# Altium schematic sheet Peripheral_1.SchDoc
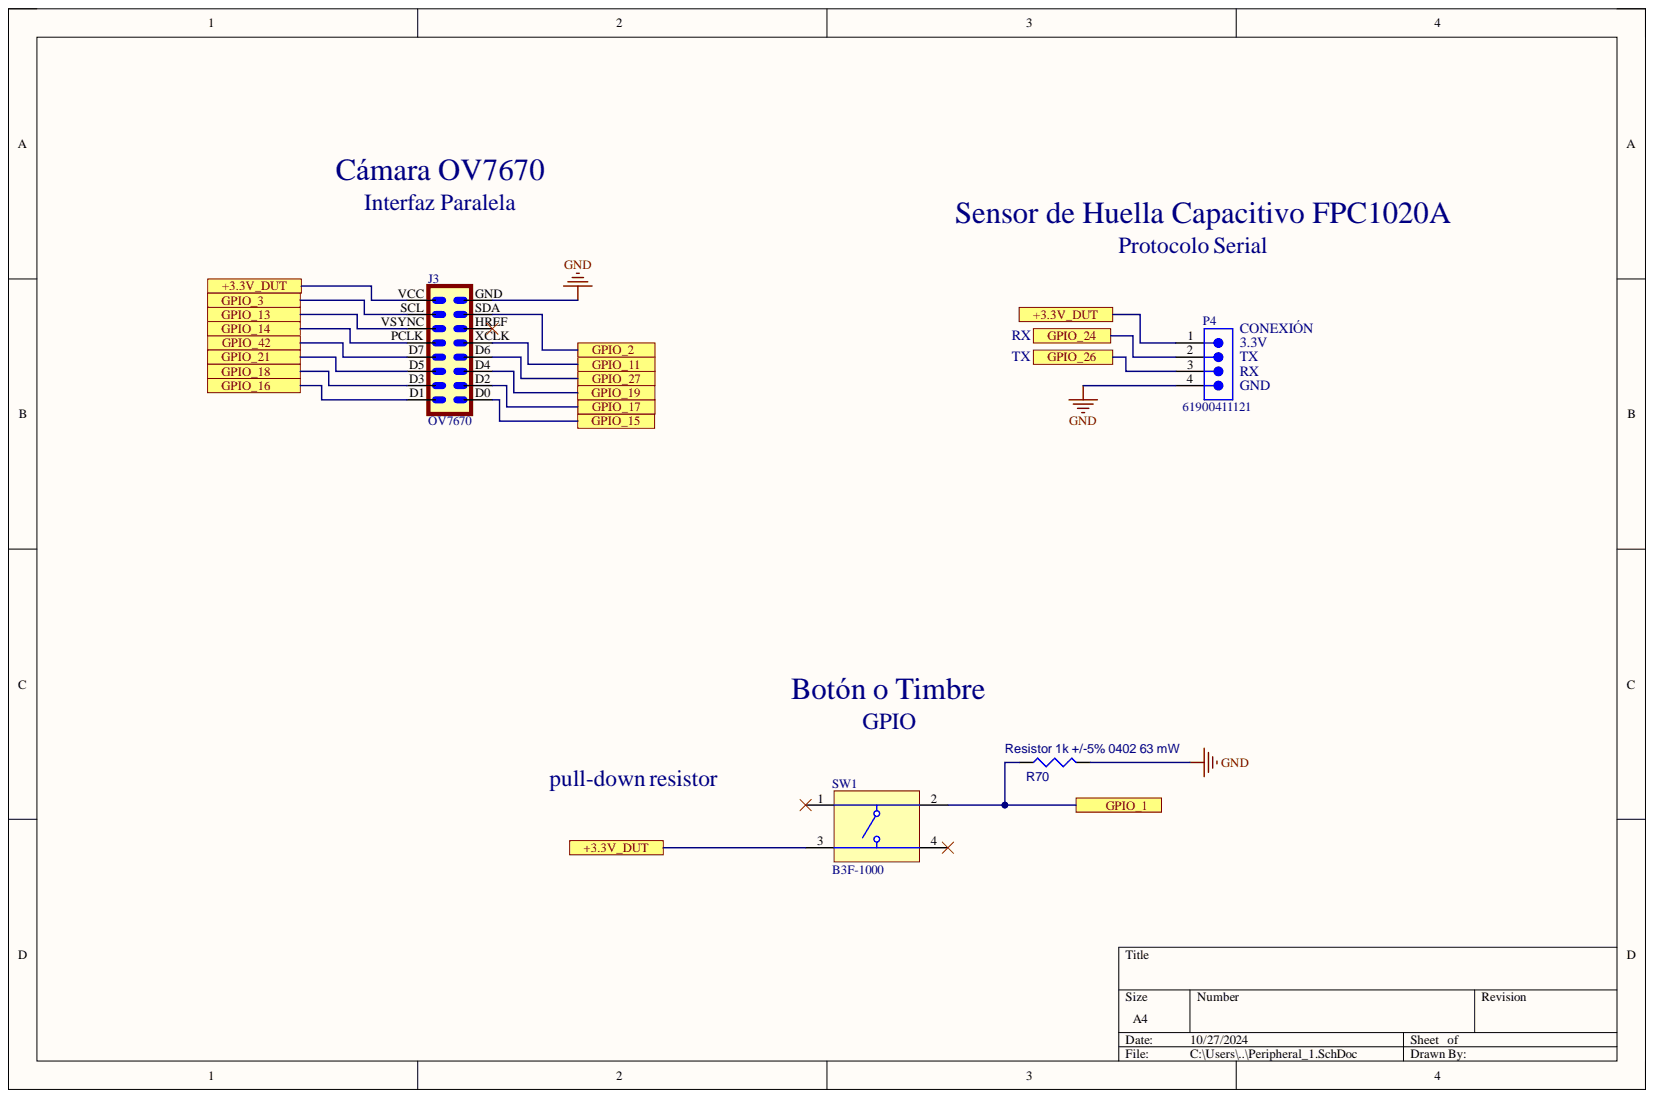
[diagram p0: Peripheral_1.SchDoc]

## Parts
J3  OV7670  [OV7670]
P4  61900411121  [61900411121]
R70  Resistor 1k +/-5% 0402 63 mW  value 1k  Case/Package: 0402  [RESC1005X40X25ML10T10]
SW1  B3F-1000  [OMRON-B3F-1000-4_V]

## Ports
+3.3V_DUT, GPIO_1, GPIO_2, GPIO_3, GPIO_11, GPIO_13, GPIO_14, GPIO_15, GPIO_16, GPIO_17, GPIO_18, GPIO_19, GPIO_21, GPIO_24, GPIO_26, GPIO_27, GPIO_42

## Nets
GND: J3.GND P4.4 R70.2
+3.3V_DUT: J3.VCC P4.1 SW1.3 ; port +3.3V_DUT
GPIO_1: R70.1 SW1.2 ; port GPIO_1
GPIO_2: J3.SDA ; port GPIO_2
GPIO_3: J3.SCL ; port GPIO_3
GPIO_11: J3.XCLK ; port GPIO_11
GPIO_13: J3.VSYNC ; port GPIO_13
GPIO_14: J3.PCLK ; port GPIO_14
GPIO_15: J3.D0 ; port GPIO_15
GPIO_16: J3.D1 ; port GPIO_16
GPIO_17: J3.D2 ; port GPIO_17
GPIO_18: J3.D3 ; port GPIO_18
GPIO_19: J3.D4 ; port GPIO_19
GPIO_21: J3.D5 ; port GPIO_21
GPIO_24: P4.2 ; port GPIO_24
GPIO_26: P4.3 ; port GPIO_26
GPIO_27: J3.D6 ; port GPIO_27
GPIO_42: J3.D7 ; port GPIO_42
NetJ3_HREF: J3.HREF
NetSW1_1: SW1.1
NetSW1_4: SW1.4

## Library data of the parts
J3 = OV7670: MF: Not Specified; Availability: In Stock; Check_prices: https://www.snapeda.com/parts/OV7670/CIF/view-part/?ref=eda; Component Kind: Standard; Library Name: OV7670.IntLib; MP: OV7670; Package: None; Price: None; SnapEDA_Link: https://www.snapeda.com/parts/OV7670/CIF/view-part/?ref=snap
P4 = CMP-1502-00586-2: NexarPartId: 18818226; Connector Type: Male Pin; Contact Resistance: 20mΩ; Current Rating: 3A; Gender: Male; Insulation: Insulated; Insulation Resistance: 1GΩ; Number of Contacts: 4; Operating Supply Voltage: 250V; Pitch: 2.54mm; Color: Beige; Component Kind: Standard; Contact Gender: Male; Contact Material: Copper; Contact Mating Length: 7.493mm; Contact Plating: Tin; Fastening Type: Friction; Flammability Rating: UL94 V-0; Height: 3.3mm; Housing Material: Nylon; Lead Free: Lead Free; Lead Pitch: 2.54mm; Length: 10.16mm; Library Name: Altium Content Vault; Max Operating Temperature: 105°C; Min Operating Temperature: -40°C; Mount: Through Hole; Number of Pins: 4; Number of Positions: 4; Number of Rows: 1; Orientation: Vertical; Packaging: Bulk; REACH SVHC: Unknown; RoHS: Compliant; Termination: Through Hole; Voltage Rating: 250V; Width: 10.2mm
R70 = CMP-009-00013-5: Chip Resistor, 1 KOhm, +/- 5%, 0.063 W, -55 to 155 degC, 0402 (1005 Metric); Power: 63mW; Component Kind: Standard; Library Name: Altium Personal Space; Max Operating Temperature: 155°C; Min Operating Temperature: -55°C; Mounting Technology: SM; Pins: 2; RoHS Compliant: True; Tolerance: 5%
SW1 = CMP-011-00004-2: Tactile Switch, SPST-NO, 0.05 A, 24 V, -25 to 70 degC, 4-Pin THD, RoHS, Bulk; Manufacturer: Omron Electronic Components; Contact Current Rating: 50mA; Max Contact Resistance: 100mohm; Max Voltage Rating (DC): 24V; Operating Force: 100gf; Resistance: 0.1Ohm; Throw Configuration: SPST; Case/Package: B3F-1000; Component Kind: Standard; Contact Material: Gold; Contact Plating: Gold; Current Rating: 50mA; Datasheet URL: http://www.omron.com/ecb/products/pdf/en-b3f.pdf; Datasheet Version: Jan-15; Library Name: Altium Personal Space; Manufacturer URL: http://www.omron.com/; Max Current Rating: 50mA; Max Operating Temperature: 70°C; Min Operating Temperature: -25°C; Mounting Technology: TH; Package Description: 4-Pin Through Hole Device, Body 6 x 6 mm; Package Quantity: 1500; Package Reference: B3F-1000; Packaging: Bulk; Pins: 4; RoHS Compliant: True; Voltage Rating: 24V; Voltage Rating (DC): 24V
